# Altium schematic sheet Debug.SchDoc(Debug)
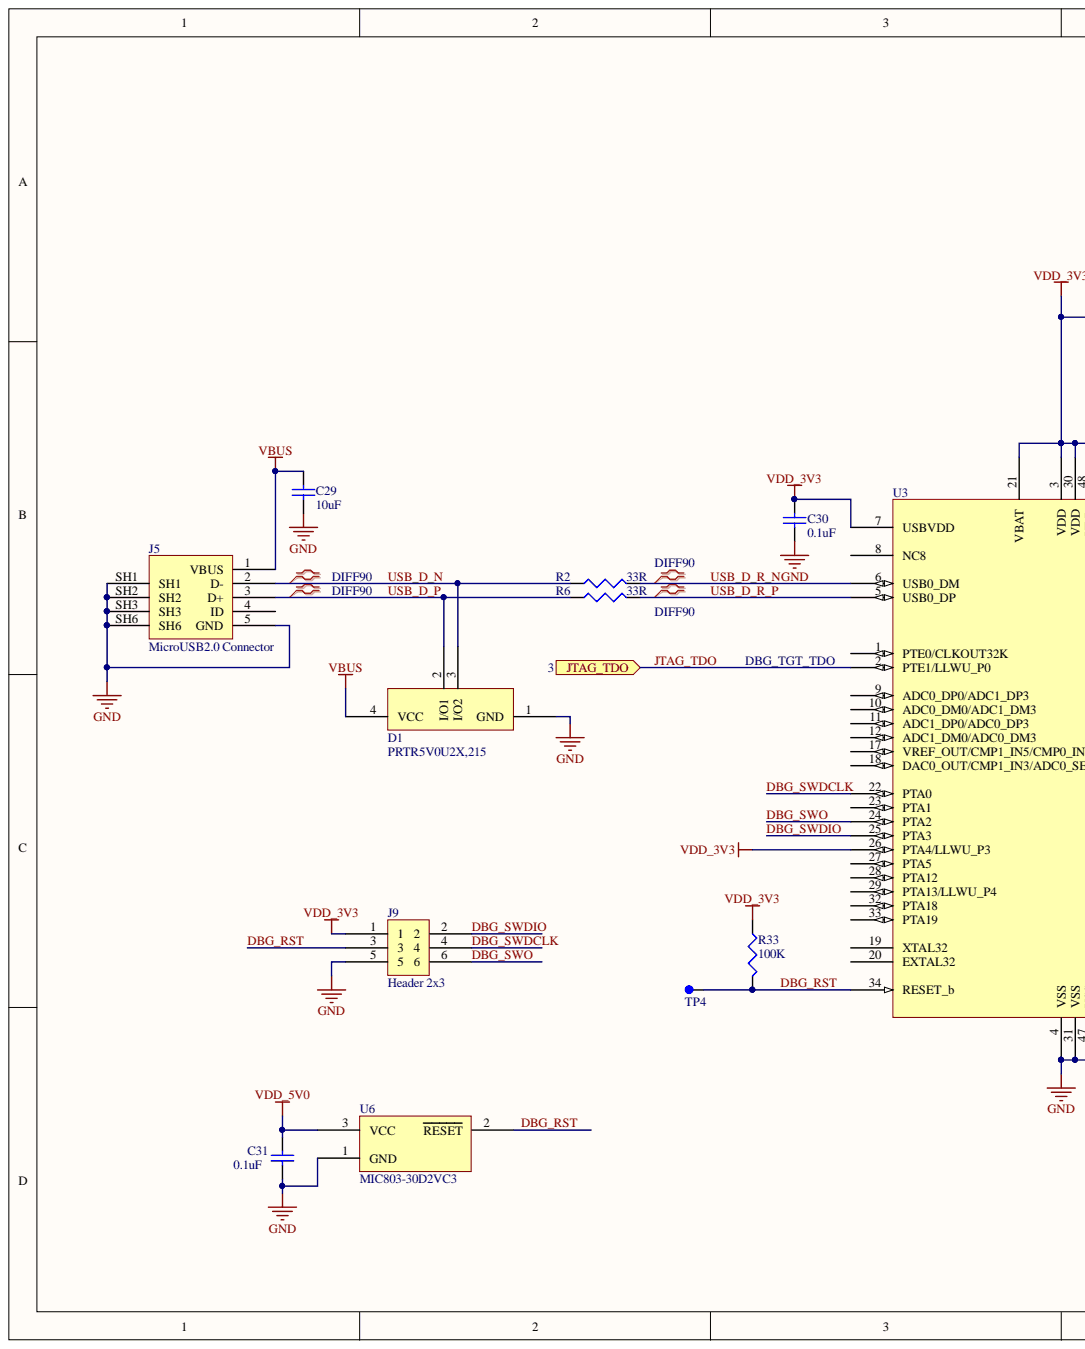
[diagram p0: Debug.SchDoc, left]
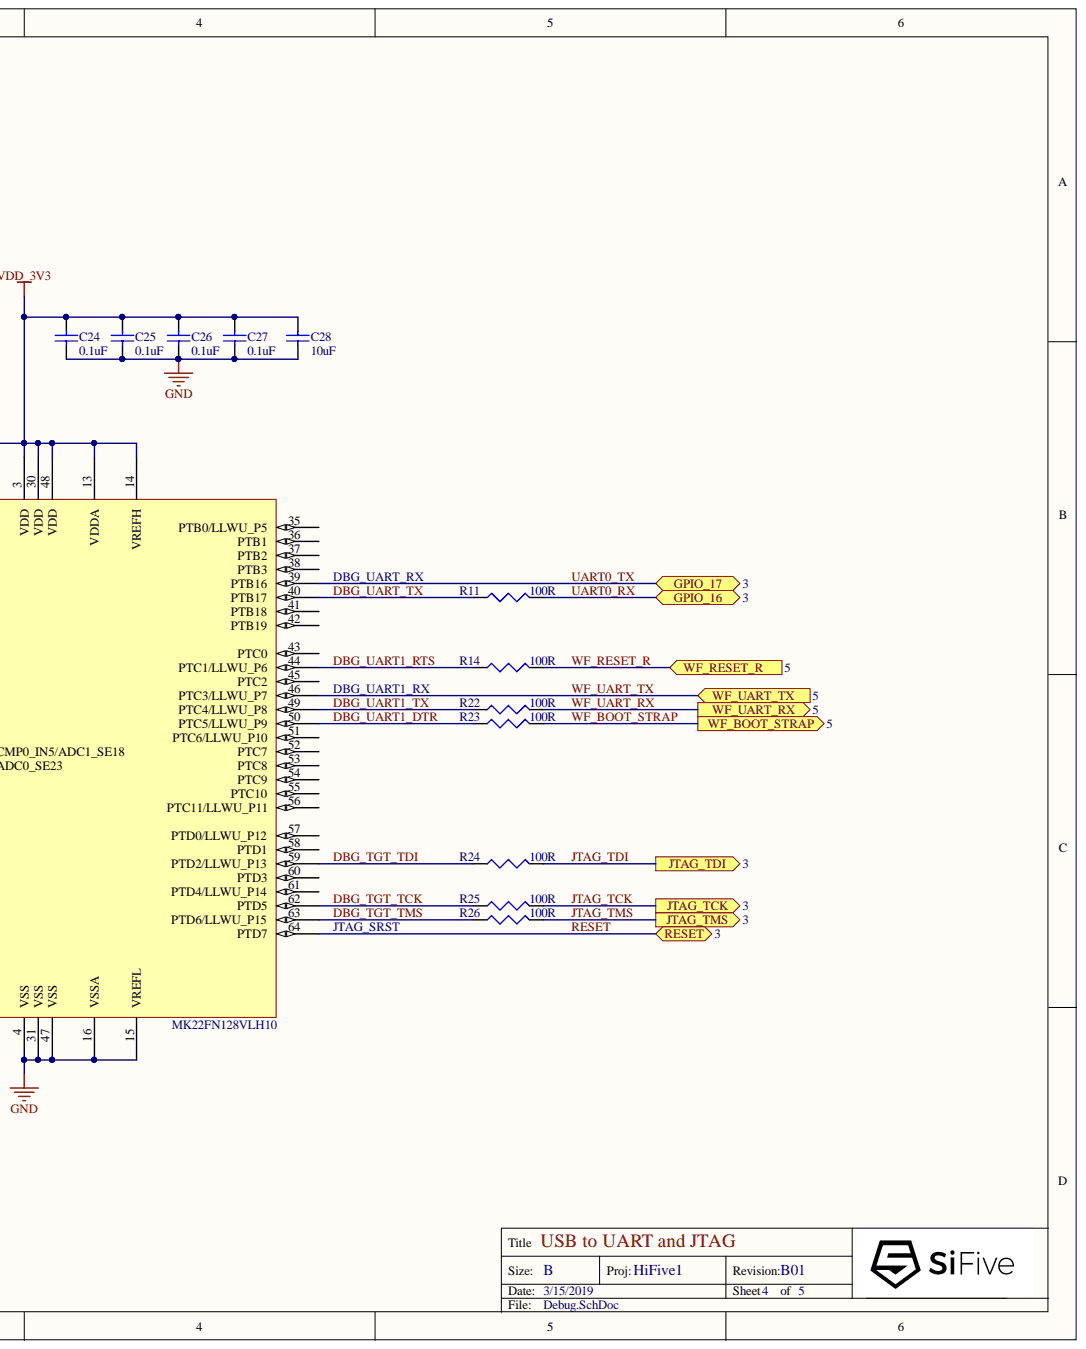
[diagram p1: Debug.SchDoc, right]

## Parts
C24  0.1uF  [C0402]
C25  0.1uF  [C0402]
C26  0.1uF  [C0402]
C27  0.1uF  [C0402]
C28  10uF  [C0603]
C29  10uF  [C0603]
C30  0.1uF  [C0402]
C31  0.1uF  [C0402]
D1  PRTR5V0U2X,215  [SOT-143B]
J5  MicroUSB2.0 Connector  [FCI_10118194-0001LF]
J9  Header 2x3  [TC2030-IDC-FP]  (no BOM)
R2  33R  [R0402]
R6  33R  [R0402]
R11  100R  [R0402]
R14  100R  [R0402]
R22  100R  [R0402]
R23  100R  [R0402]
R24  100R  [R0402]
R25  100R  [R0402]
R26  100R  [R0402]
R33  100K  [R0402]
TP4  Testpoint  [Testpoint_SMT]  (value not drawn)  (no BOM)
U3  MK22FN128VLH10  [LQFP-64]
U6  MIC803-30D2VC3  [SOT65P210X110-3N_A]

## Ports
GPIO_16, GPIO_17, JTAG_TCK, JTAG_TDI, JTAG_TDO, JTAG_TMS, RESET, WF_BOOT_STRAP, WF_RESET_R, WF_UART_RX, WF_UART_TX

## Nets
GND: C24.2 C25.2 C26.2 C27.2 C28.1 C29.1 C30.2 C31.2 D1.1(GND) J5.5(GND) J5.SH1 J5.SH2 J5.SH3 J5.SH6 J9.5 U3.4(VSS) U3.15(VREFL) U3.16(VSSA) U3.31(VSS) U3.47(VSS) U6.1
VBUS: C29.2 D1.4(VCC) J5.1(VBUS)
VDD_3V3: C24.1 C25.1 C26.1 C27.1 C28.2 C30.1 J9.1 R33.1 U3.3(VDD) U3.7(USBVDD) U3.13(VDDA) U3.14(VREFH) U3.21(VBAT) U3.26(PTA4/LLWU_P3) U3.30(VDD) U3.48(VDD)
VDD_5V0: C31.1 U6.3
DBG_RST: J9.3 R33.2 TP4.1 U3.34(RESET_b) U6.2
DBG_SWDCLK: J9.4 U3.22(PTA0)
DBG_SWDIO: J9.2 U3.25(PTA3)
DBG_SWO: J9.6 U3.24(PTA2)
DBG_TGT_TCK: R25.1 U3.62(PTD5)
DBG_TGT_TDI: R24.1 U3.59(PTD2/LLWU_P13)
DBG_TGT_TMS: R26.1 U3.63(PTD6/LLWU_P15)
DBG_UART1_DTR: R23.1 U3.50(PTC5/LLWU_P9)
DBG_UART1_RTS: R14.1 U3.44(PTC1/LLWU_P6)
DBG_UART1_TX: R22.1 U3.49(PTC4/LLWU_P8)
DBG_UART_TX: R11.1 U3.40(PTB17)
JTAG_TCK: R25.2 ; port JTAG_TCK
JTAG_TDI: R24.2 ; port JTAG_TDI
JTAG_TDO: U3.2(PTE1/LLWU_P0) ; port JTAG_TDO
JTAG_TMS: R26.2 ; port JTAG_TMS
RESET: U3.64(PTD7) ; port RESET
UART0_RX: R11.2 ; port GPIO_16
UART0_TX: U3.39(PTB16) ; port GPIO_17
USB_D_N: D1.3(I/O2) J5.2(D-) R2.1
USB_D_P: D1.2(I/O1) J5.3(D+) R6.1
USB_D_R_N: R2.2 U3.6(USB0_DM)
USB_D_R_P: R6.2 U3.5(USB0_DP)
WF_BOOT_STRAP: R23.2 ; port WF_BOOT_STRAP
WF_RESET_R: R14.2 ; port WF_RESET_R
WF_UART_RX: R22.2 ; port WF_UART_RX
WF_UART_TX: U3.46(PTC3/LLWU_P7) ; port WF_UART_TX

Not connected: J5 4(ID); U3 1(PTE0/CLKOUT32K) 8(NC8) 9(ADC0_DP0/ADC1_DP3) 10(ADC0_DM0/ADC1_DM3) 11(ADC1_DP0/ADC0_DP3) 12(ADC1_DM0/ADC0_DM3) 17(VREF_OUT/CMP1_IN5/CMP0_IN5/ADC1_SE18) 18(DAC0_OUT/CMP1_IN3/ADC0_SE23) 19(XTAL32) 20(EXTAL32) 23(PTA1) 27(PTA5) 28(PTA12) 29(PTA13/LLWU_P4) 32(PTA18) 33(PTA19) 35(PTB0/LLWU_P5) 36(PTB1) 37(PTB2) 38(PTB3) 41(PTB18) 42(PTB19) 43(PTC0) 45(PTC2) 51(PTC6/LLWU_P10) 52(PTC7) 53(PTC8) 54(PTC9) 55(PTC10) 56(PTC11/LLWU_P11) 57(PTD0/LLWU_P12) 58(PTD1) 60(PTD3) 61(PTD4/LLWU_P14)

## Library data of the parts
C24-C27 C30 C31 = C0100004: 0.10µF 16V Ceramic Capacitor X7R 0402; Manufacturer PN: CL05B104KO5NFNC; Manufacturer: Samsung Electro-Mechanics; Tolerance: ±10%; Database Table Name: Capacitors; Voltage Rating: 16V; Value: 0.1uF; Type: X7R; Part Number: C0100004; Operating Temperature: -55°C ~ 125°C; Library Name: PartLibrary.DbLib; Component Kind: Standard
C28 C29 = C0100033: CAP CER 10UF 10V X5R 0603; Manufacturer PN: GRM188R61A106KE69J; Manufacturer: Murata Electronics; Tolerance: ±10%; Database Table Name: Capacitors; Voltage Rating: 10V; Value: 10uF; Type: X5R; Part Number: C0100033; Operating Temperature: -55°C ~ 85°C; Library Name: PartLibrary.DbLib; Component Kind: Standard
D1 = D0000012: TVS DIODE 5.5V SOT143B; Manufacturer: Nexperia; Voltage Breakdown: 6V; Database Table Name: Diodes; Voltage Reverse Standoff: 5.5V; Value: PRTR5V0U2X,215; Temperature Range: -40°C ~ 85°C; Part Number: D0000012; Manufacturer PN: PRTR5V0U2X,215; Library Name: PartLibrary.DbLib; Component Kind: Standard
J5 = J0000048: USB - micro B USB 2.0 Receptacle Connector 5 Position Surface Mount, Right Angle, Horizontal; Manufacturer PN: 10118194-0001LF; Manufacturer: Amphenol FCI; Database Table Name: Connectors; Part Number: J0000048; Library Name: PartLibrary.DbLib; Component Kind: Standard
J9 = J0000045: 2x3 Connector, Small footprint, no legs, no component; Manufacturer: Tag Connect; Database Table Name: Connectors; Part Number: J0000045; Manufacturer PN: TC2030-IDC-FP; Library Name: PartLibrary.DbLib
R2 R6 = R0000044: RES SMD 33 OHM 1% 1/16W 0402; Manufacturer PN: RC0402FR-0733RL; Manufacturer: Yageo; Power Rating: 0.063W; Database Table Name: Resistors; Value: 33R; Type: Thick Film; Tolerance: ±1%; Temperature Range: -55°C ~ 155°C; Part Number: R0000044; Library Name: PartLibrary.DbLib; Component Kind: Standard
R11 R14 R22-R26 = R0000020: RES SMD 100 OHM 1% 1/16W 0402; Manufacturer PN: RC0402FR-07100RL; Manufacturer: Yageo; Power Rating: 0.063W; Database Table Name: Resistors; Value: 100R; Type: Thick Film; Tolerance: ±1%; Temperature Range: -55°C ~ 155°C; Part Number: R0000020; Library Name: PartLibrary.DbLib; Component Kind: Standard
R33 = R0000012: RES SMD 100K OHM 1% 1/16W 0402; Manufacturer PN: RC0402FR-07100KL; Manufacturer: Yageo; Power Rating: 0.063W; Database Table Name: Resistors; Value: 100K; Type: Thick Film; Tolerance: ±1%; Temperature Range: -55°C ~ 155°C; Part Number: R0000012; Library Name: PartLibrary.DbLib; Component Kind: Standard
TP4 = M0000002: Test Point SMT; Database Table Name: Misc; Part Number: M0000002; Library Name: PartLibrary.DbLib
U3 = U0000058: ARM® Cortex®-M4 Kinetis K20 Microcontroller IC 32-Bit 100MHz 128KB (128K x 8) FLASH 64-LQFP (10x10); Manufacturer: NXP USA Inc; Value: MK22FN128VLH10; Temperature Range: -40°C ~ 105°C; Part Number: U0000058; Manufacturer PN: MK22FN128VLH10; Library Name: PartLibrary.DbLib; Database Table Name: IC; Component Kind: Standard
U6 = U0000076: IC MPU SUPERVISOR SC70-3; Manufacturer: Microchip; Value: MIC803-30D2VC3; Temperature Range: -40°C ~ 125°C; Part Number: U0000076; Manufacturer PN: MIC803-30D2VC3; Library Name: PartLibrary.DbLib; Database Table Name: IC; Component Kind: Standard
